# Altium schematic sheet Core.SchDoc(Core)
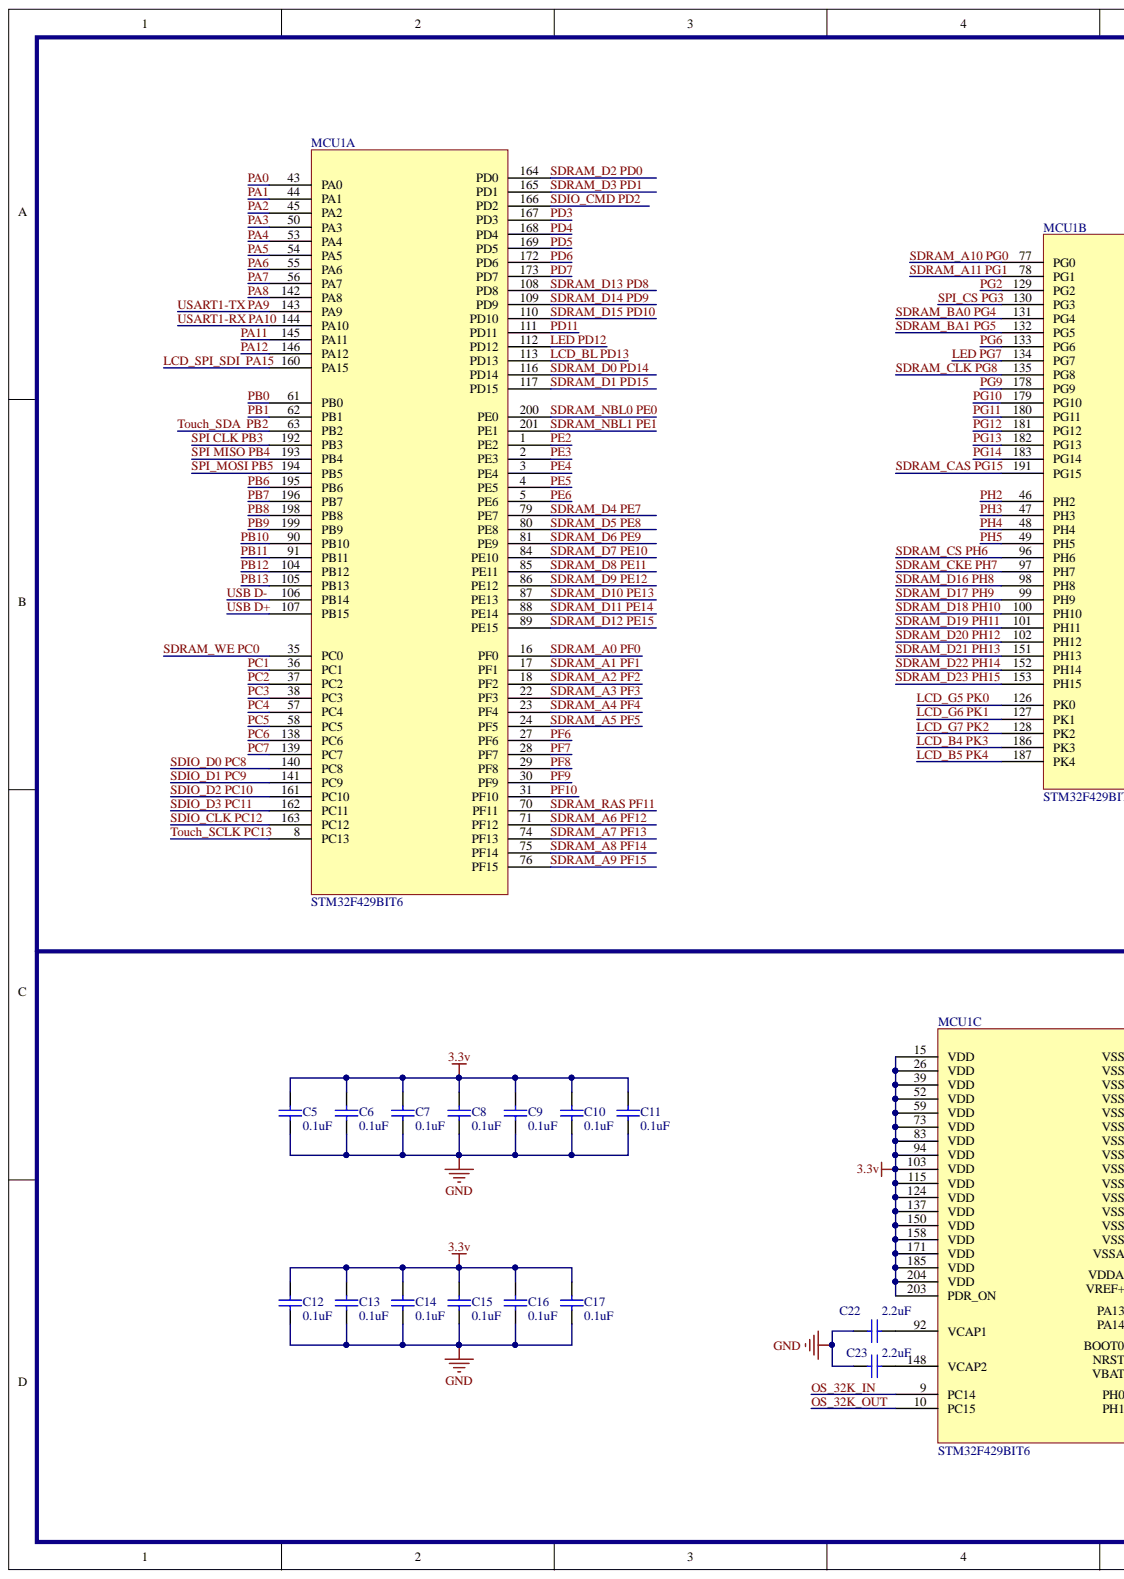
[diagram p0: Core.SchDoc, left]
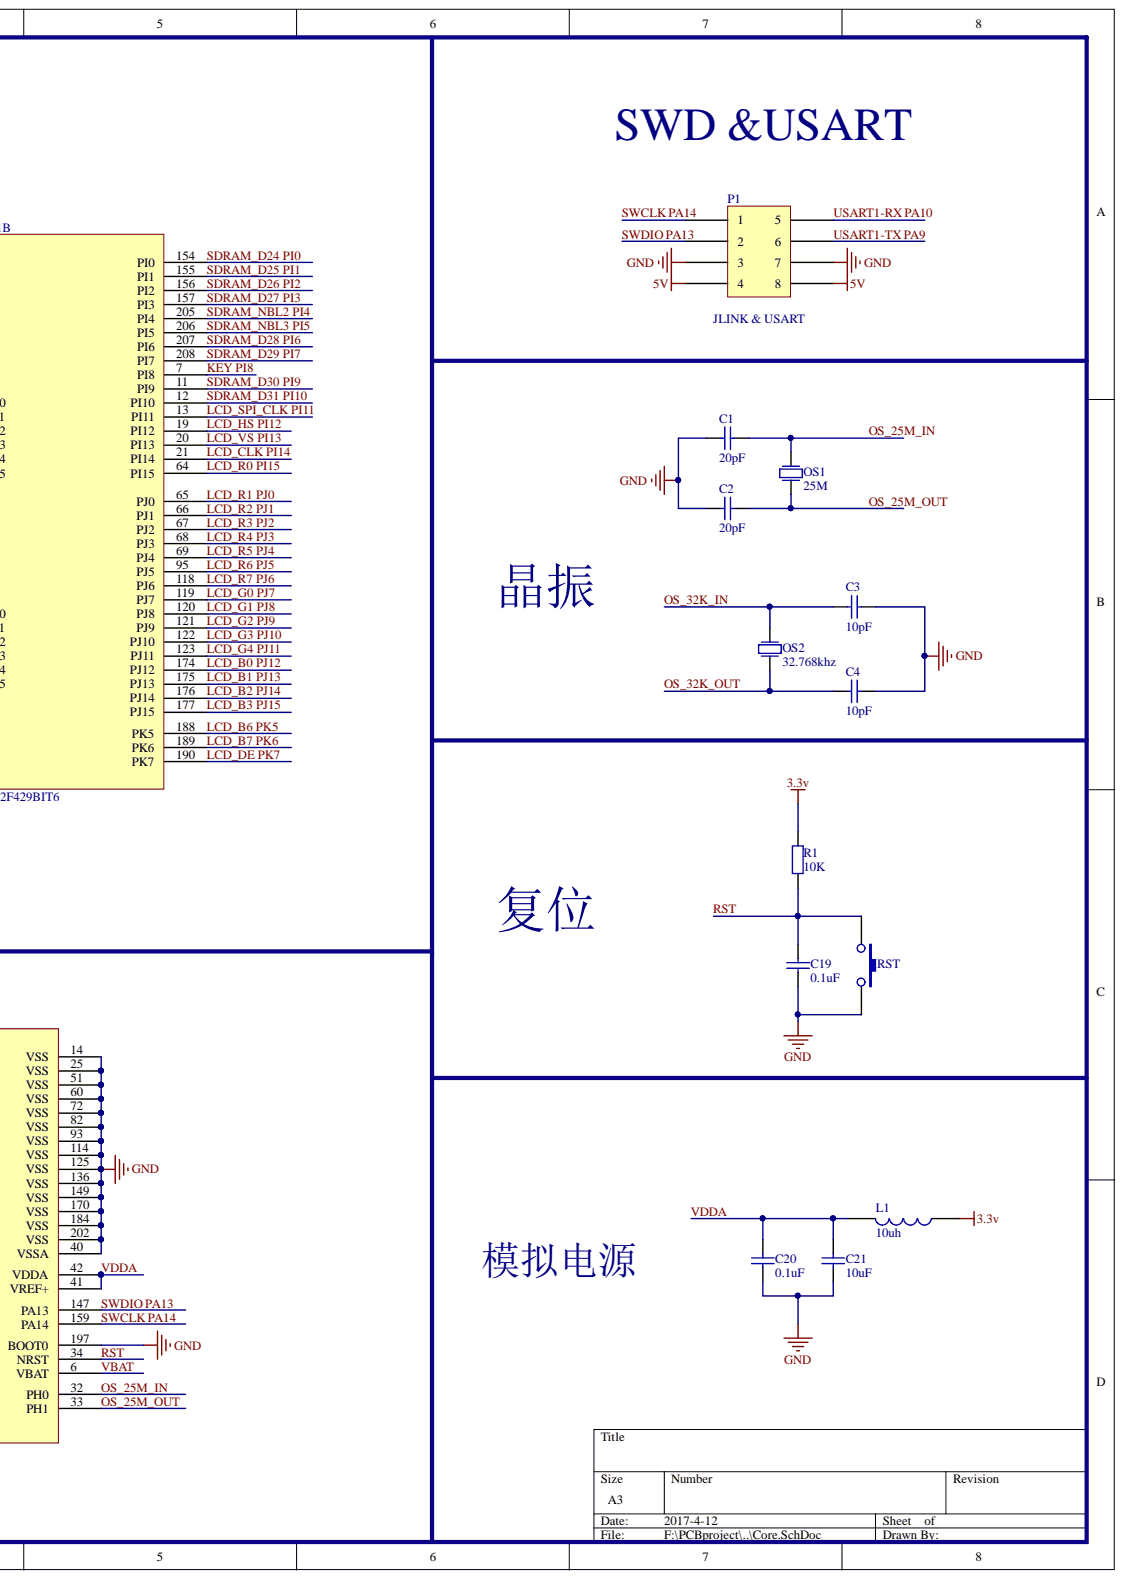
[diagram p1: Core.SchDoc, right]

## Parts
C1  20pF  [0603-cap]
C2  20pF  [0603-cap]
C3  10pF  [0603-cap]
C4  10pF  [0603-cap]
C5  0.1uF  [0603-cap]
C6  0.1uF  [0603-cap]
C7  0.1uF  [0603-cap]
C8  0.1uF  [0603-cap]
C9  0.1uF  [0603-cap]
C10  0.1uF  [0603-cap]
C11  0.1uF  [0603-cap]
C12  0.1uF  [0603-cap]
C13  0.1uF  [0603-cap]
C14  0.1uF  [0603-cap]
C15  0.1uF  [0603-cap]
C16  0.1uF  [0603-cap]
C17  0.1uF  [0603-cap]
C19  0.1uF  [0603-cap]
C20  0.1uF  [0603-cap]
C21  10uF  [0603-cap]
C22  2.2uF  [0603-cap]
C23  2.2uF  [0603-cap]
L1  10uh  [0603-res]
MCU1  STM32F429BIT6  [LQFP-208-0.5mm]  (parts A,B,C)
OS1  25M  [3225]
OS2  晶振  [FC135-3.2X1.5]  (value not drawn)
P1  JLINK & USART  [2X4P-2.5MM-SMD]
R1  10K  [0603-res]
RST  按键  [SWT6*3]  (value not drawn)

## Nets
3.3V: C5.2 C6.2 C7.2 C8.2 C9.2 C10.2 C11.2 C12.2 C13.2 C14.2 C15.2 C16.2 C17.2 L1.1 MCU1.15(VDD) MCU1.26(VDD) MCU1.39(VDD) MCU1.52(VDD) MCU1.59(VDD) MCU1.73(VDD) MCU1.83(VDD) MCU1.94(VDD) MCU1.103(VDD) MCU1.115(VDD) MCU1.124(VDD) MCU1.137(VDD) MCU1.150(VDD) MCU1.158(VDD) MCU1.171(VDD) MCU1.185(VDD) MCU1.203(PDR_ON) MCU1.204(VDD) R1.2
5V: P1.4 P1.8
GND: C1.1 C2.1 C3.2 C4.2 C5.1 C6.1 C7.1 C8.1 C9.1 C10.1 C11.1 C12.1 C13.1 C14.1 C15.1 C16.1 C17.1 C19.1 C20.1 C21.2 C22.1 C23.1 MCU1.14(VSS) MCU1.25(VSS) MCU1.40(VSSA) MCU1.51(VSS) MCU1.60(VSS) MCU1.72(VSS) MCU1.82(VSS) MCU1.93(VSS) MCU1.114(VSS) MCU1.125(VSS) MCU1.136(VSS) MCU1.149(VSS) MCU1.170(VSS) MCU1.184(VSS) MCU1.197(BOOT0) MCU1.202(VSS) P1.3 P1.7 RST.2
KEY PI8: MCU1.7(PI8)
LCD_B0 PJ12: MCU1.174(PJ12)
LCD_B1 PJ13: MCU1.175(PJ13)
LCD_B2 PJ14: MCU1.176(PJ14)
LCD_B3 PJ15: MCU1.177(PJ15)
LCD_B4 PK3: MCU1.186(PK3)
LCD_B5 PK4: MCU1.187(PK4)
LCD_B6 PK5: MCU1.188(PK5)
LCD_B7 PK6: MCU1.189(PK6)
LCD_BL PD13: MCU1.113(PD13)
LCD_CLK PI14: MCU1.21(PI14)
LCD_DE PK7: MCU1.190(PK7)
LCD_G0 PJ7: MCU1.119(PJ7)
LCD_G1 PJ8: MCU1.120(PJ8)
LCD_G2 PJ9: MCU1.121(PJ9)
LCD_G3 PJ10: MCU1.122(PJ10)
LCD_G4 PJ11: MCU1.123(PJ11)
LCD_G5 PK0: MCU1.126(PK0)
LCD_G6 PK1: MCU1.127(PK1)
LCD_G7 PK2: MCU1.128(PK2)
LCD_HS PI12: MCU1.19(PI12)
LCD_R0 PI15: MCU1.64(PI15)
LCD_R1 PJ0: MCU1.65(PJ0)
LCD_R2 PJ1: MCU1.66(PJ1)
LCD_R3 PJ2: MCU1.67(PJ2)
LCD_R4 PJ3: MCU1.68(PJ3)
LCD_R5 PJ4: MCU1.69(PJ4)
LCD_R6 PJ5: MCU1.95(PJ5)
LCD_R7 PJ6: MCU1.118(PJ6)
LCD_SPI_CLK PI11: MCU1.13(PI11)
LCD_SPI_SDI  PA15: MCU1.160(PA15)
LCD_VS PI13: MCU1.20(PI13)
LED PD12: MCU1.112(PD12)
LED PG7: MCU1.134(PG7)
OS_25M_IN: C1.2 MCU1.32(PH0) OS1.1
OS_25M_OUT: C2.2 MCU1.33(PH1) OS1.2
OS_32K_IN: C3.1 MCU1.9(PC14) OS2.1
OS_32K_OUT: C4.1 MCU1.10(PC15) OS2.2
PA0: MCU1.43(PA0)
PA1: MCU1.44(PA1)
PA2: MCU1.45(PA2)
PA3: MCU1.50(PA3)
PA4: MCU1.53(PA4)
PA5: MCU1.54(PA5)
PA6: MCU1.55(PA6)
PA7: MCU1.56(PA7)
PA8: MCU1.142(PA8)
PA11: MCU1.145(PA11)
PA12: MCU1.146(PA12)
PB0: MCU1.61(PB0)
PB1: MCU1.62(PB1)
PB6: MCU1.195(PB6)
PB7: MCU1.196(PB7)
PB8: MCU1.198(PB8)
PB9: MCU1.199(PB9)
PB10: MCU1.90(PB10)
PB11: MCU1.91(PB11)
PB12: MCU1.104(PB12)
PB13: MCU1.105(PB13)
PC1: MCU1.36(PC1)
PC2: MCU1.37(PC2)
PC3: MCU1.38(PC3)
PC4: MCU1.57(PC4)
PC5: MCU1.58(PC5)
PC6: MCU1.138(PC6)
PC7: MCU1.139(PC7)
PD3: MCU1.167(PD3)
PD4: MCU1.168(PD4)
PD5: MCU1.169(PD5)
PD6: MCU1.172(PD6)
PD7: MCU1.173(PD7)
PD11: MCU1.111(PD11)
PE2: MCU1.1(PE2)
PE3: MCU1.2(PE3)
PE4: MCU1.3(PE4)
PE5: MCU1.4(PE5)
PE6: MCU1.5(PE6)
PF6: MCU1.27(PF6)
PF7: MCU1.28(PF7)
PF8: MCU1.29(PF8)
PF9: MCU1.30(PF9)
PF10: MCU1.31(PF10)
PG2: MCU1.129(PG2)
PG6: MCU1.133(PG6)
PG9: MCU1.178(PG9)
PG10: MCU1.179(PG10)
PG11: MCU1.180(PG11)
PG12: MCU1.181(PG12)
PG13: MCU1.182(PG13)
PG14: MCU1.183(PG14)
PH2: MCU1.46(PH2)
PH3: MCU1.47(PH3)
PH4: MCU1.48(PH4)
PH5: MCU1.49(PH5)
RST: C19.2 MCU1.34(NRST) R1.1 RST.1
SDIO_CLK PC12: MCU1.163(PC12)
SDIO_CMD PD2: MCU1.166(PD2)
SDIO_D0 PC8: MCU1.140(PC8)
SDIO_D1 PC9: MCU1.141(PC9)
SDIO_D2 PC10: MCU1.161(PC10)
SDIO_D3 PC11: MCU1.162(PC11)
SDRAM_A0 PF0: MCU1.16(PF0)
SDRAM_A1 PF1: MCU1.17(PF1)
SDRAM_A2 PF2: MCU1.18(PF2)
SDRAM_A3 PF3: MCU1.22(PF3)
SDRAM_A4 PF4: MCU1.23(PF4)
SDRAM_A5 PF5: MCU1.24(PF5)
SDRAM_A6 PF12: MCU1.71(PF12)
SDRAM_A7 PF13: MCU1.74(PF13)
SDRAM_A8 PF14: MCU1.75(PF14)
SDRAM_A9 PF15: MCU1.76(PF15)
SDRAM_A10 PG0: MCU1.77(PG0)
SDRAM_A11 PG1: MCU1.78(PG1)
SDRAM_BA0 PG4: MCU1.131(PG4)
SDRAM_BA1 PG5: MCU1.132(PG5)
SDRAM_CAS PG15: MCU1.191(PG15)
SDRAM_CKE PH7: MCU1.97(PH7)
SDRAM_CLK PG8: MCU1.135(PG8)
SDRAM_CS PH6: MCU1.96(PH6)
SDRAM_D0 PD14: MCU1.116(PD14)
SDRAM_D1 PD15: MCU1.117(PD15)
SDRAM_D2 PD0: MCU1.164(PD0)
SDRAM_D3 PD1: MCU1.165(PD1)
SDRAM_D4 PE7: MCU1.79(PE7)
SDRAM_D5 PE8: MCU1.80(PE8)
SDRAM_D6 PE9: MCU1.81(PE9)
SDRAM_D7 PE10: MCU1.84(PE10)
SDRAM_D8 PE11: MCU1.85(PE11)
SDRAM_D9 PE12: MCU1.86(PE12)
SDRAM_D10 PE13: MCU1.87(PE13)
SDRAM_D11 PE14: MCU1.88(PE14)
SDRAM_D12 PE15: MCU1.89(PE15)
SDRAM_D13 PD8: MCU1.108(PD8)
SDRAM_D14 PD9: MCU1.109(PD9)
SDRAM_D15 PD10: MCU1.110(PD10)
SDRAM_D16 PH8: MCU1.98(PH8)
SDRAM_D17 PH9: MCU1.99(PH9)
SDRAM_D18 PH10: MCU1.100(PH10)
SDRAM_D19 PH11: MCU1.101(PH11)
SDRAM_D20 PH12: MCU1.102(PH12)
SDRAM_D21 PH13: MCU1.151(PH13)
SDRAM_D22 PH14: MCU1.152(PH14)
SDRAM_D23 PH15: MCU1.153(PH15)
SDRAM_D24 PI0: MCU1.154(PI0)
SDRAM_D25 PI1: MCU1.155(PI1)
SDRAM_D26 PI2: MCU1.156(PI2)
SDRAM_D27 PI3: MCU1.157(PI3)
SDRAM_D28 PI6: MCU1.207(PI6)
SDRAM_D29 PI7: MCU1.208(PI7)
SDRAM_D30 PI9: MCU1.11(PI9)
SDRAM_D31 PI10: MCU1.12(PI10)
SDRAM_NBL0 PE0: MCU1.200(PE0)
SDRAM_NBL1 PE1: MCU1.201(PE1)
SDRAM_NBL2 PI4: MCU1.205(PI4)
SDRAM_NBL3 PI5: MCU1.206(PI5)
SDRAM_RAS PF11: MCU1.70(PF11)
SDRAM_WE PC0: MCU1.35(PC0)
SPI CLK PB3: MCU1.192(PB3)
SPI MISO PB4: MCU1.193(PB4)
SPI_CS PG3: MCU1.130(PG3)
SPI_MOSI PB5: MCU1.194(PB5)
SWCLK PA14: MCU1.159(PA14) P1.1
SWDIO PA13: MCU1.147(PA13) P1.2
TOUCH_SCLK PC13: MCU1.8(PC13)
TOUCH_SDA  PB2: MCU1.63(PB2)
USART1-RX PA10: MCU1.144(PA10) P1.5
USART1-TX PA9: MCU1.143(PA9) P1.6
USB D+: MCU1.107(PB15)
USB D-: MCU1.106(PB14)
VBAT: MCU1.6(VBAT)
VDDA: C20.2 C21.1 L1.2 MCU1.41(VREF+) MCU1.42(VDDA)
NetC22_2: C22.2 MCU1.92(VCAP1)
NetC23_2: C23.2 MCU1.148(VCAP2)

## Library data of the parts
C1-C17 C19-C23 = Cap1: Component Kind: Standard; Library Name: MY.SCHLIB
  C1 C2: value: 20pF
  C3 C4: value: 10pF
  C5-C17 C19 C20: value: 0.1uF
  C21: value: 10uF
  C22 C23: value: 2.2uF
L1 = 电感: Component Kind: Standard; Library Name: MY.SCHLIB
OS1 = 晶振: Component Kind: Standard; Library Name: MY.SCHLIB; vaule: 25M
P1 = CON4-X2: Component Kind: Standard; Library Name: 接口.SchLib
R1 = Res: Component Kind: Standard; Library Name: MY.SCHLIB; value: 10K
MCU1 = STM32F429BIT6: Component Kind: Standard; Library Name: MY-MCU.SchLib
OS2 = 晶振: : 32.768khz; Component Kind: Standard; Library Name: MY.SCHLIB
RST = 按键: Component Kind: Standard; Library Name: MY.SCHLIB
